# Altium schematic sheet User Feedback.SchDoc
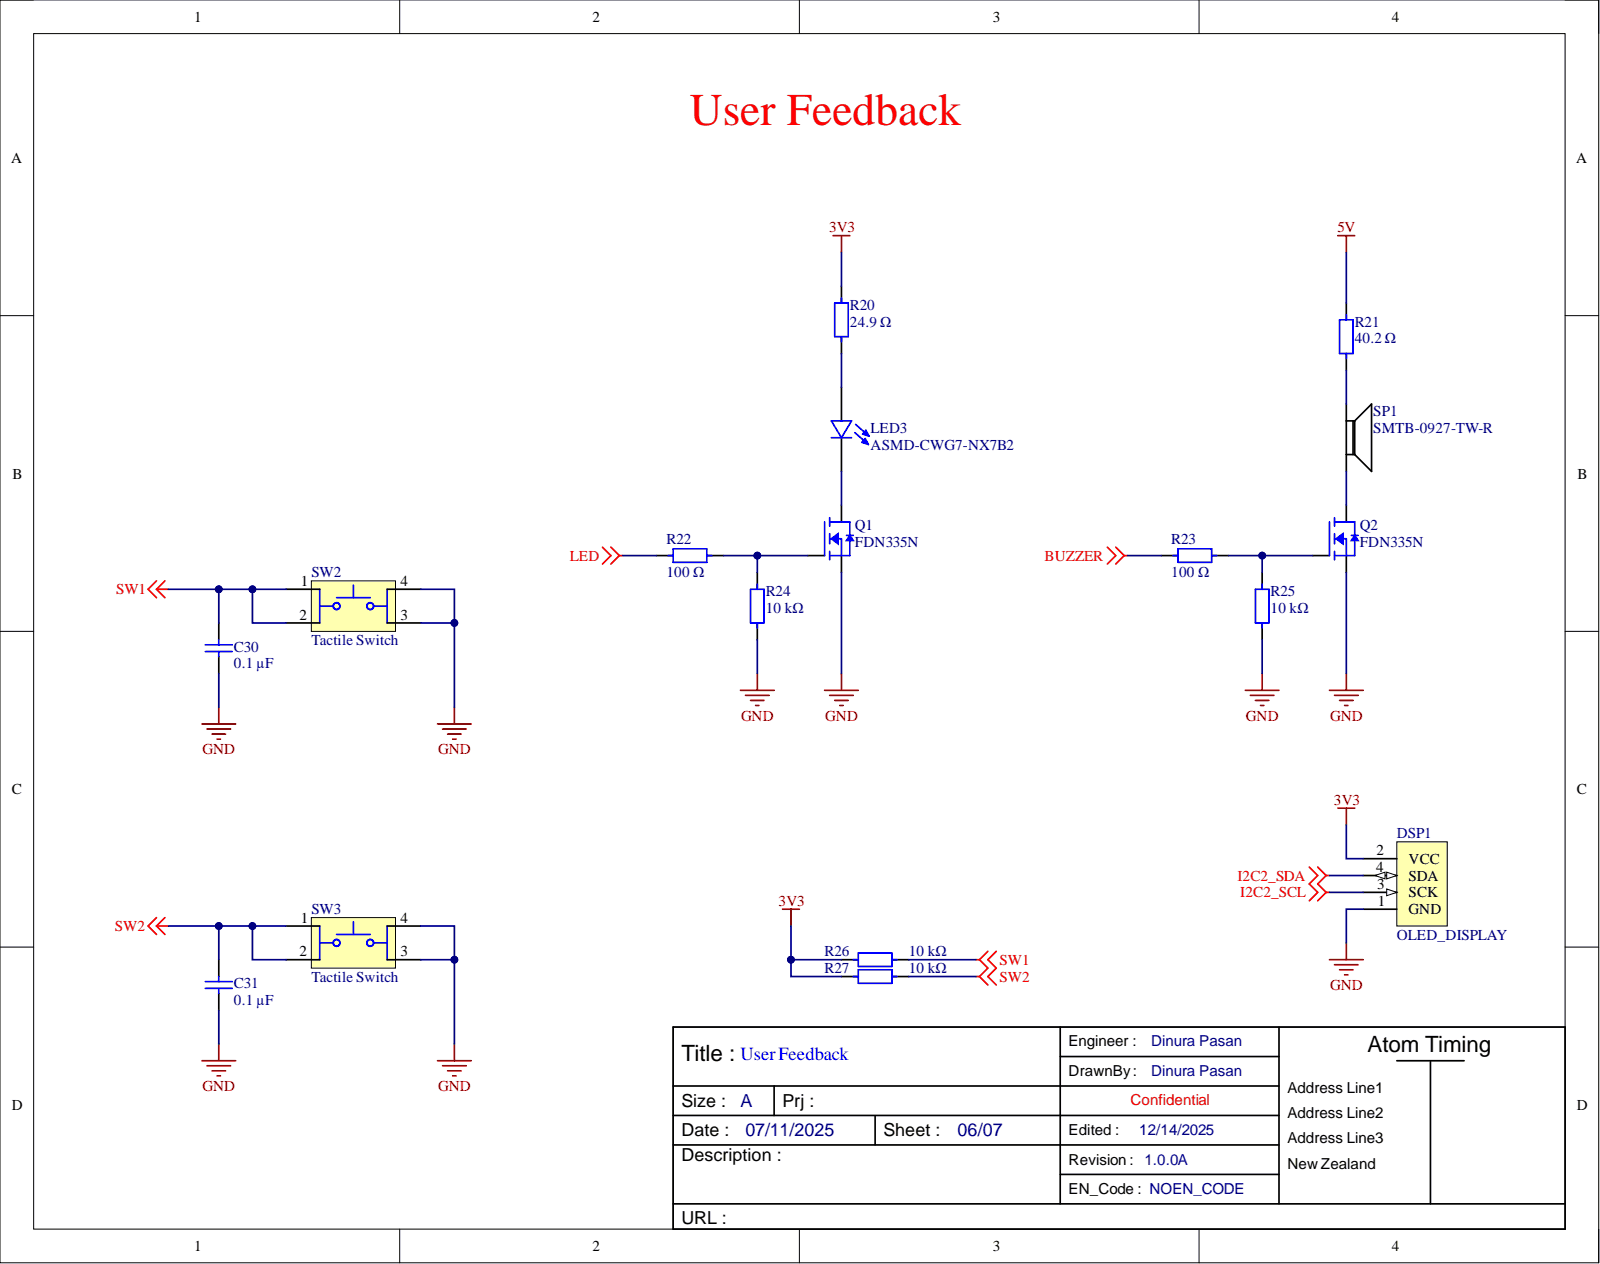
[diagram p0: User Feedback.SchDoc]

## Parts
C30  0.1 µF  [TDK_CGA0805N]
C31  0.1 µF  [TDK_CGA0805N]
DSP1  OLED_DISPLAY  [OLED_DISPLAY]
LED3  ASMD-CWG7-NX7B2  [ASMD-CWG7-NX7B2]
Q1  FDN335N  [FDN335N]
Q2  FDN335N  [FDN335N]
R20  24.9 Ω  [YAGEO_RT0603N]
R21  40.2 Ω  [YAGEO_RT0402N]
R22  100 Ω  [Panasonic_ERA2AN]
R23  100 Ω  [Panasonic_ERA2AN]
R24  10 kΩ  [Panasonic_ERA2AN]
R25  10 kΩ  [Panasonic_ERA2AN]
R26  10 kΩ  [Panasonic_ERA2AN]
R27  10 kΩ  [Panasonic_ERA2AN]
SP1  SMTB-0927-TW-R  [SMTB-0927-TW-R]
SW2  Tactile Switch  [TL3313AF160QG]
SW3  Tactile Switch  [TL3313AF160QG]

## Nets
3V3: DSP1.2(VCC) R20.2 R26.2 R27.2
5V: R21.2
BUZZER: R23.1
GND: C30.2 C31.2 DSP1.1(GND) Q1.S Q2.S R24.1 R25.1 SW2.3 SW2.4 SW3.3 SW3.4
I2C2_SCL: DSP1.3(SCK)
I2C2_SDA: DSP1.4(SDA)
LED: R22.1
SW1: C30.1 R26.1 SW2.1 SW2.2
SW2: C31.1 R27.1 SW3.1 SW3.2
NetLED3_A: LED3.A R20.1
NetLED3_K: LED3.K Q1.D
NetQ1_G: Q1.G R22.2 R24.2
NetQ2_D: Q2.D SP1.2
NetQ2_G: Q2.G R23.2 R25.2
NetR21_1: R21.1 SP1.1

## Library data of the parts
C30 C31 = CGA4J2X7R2A104K125AE: 0.1 µF ±10% 100V X7R -55°C ~ 125°C 0805 (2012 Metric); Manufacturer: TDK Corporation; Database Table Name: Sheet1$; Eco Plan: RoHS & Green; Initial Voltage: 0V; TEMP: 25; Value: 0.1u; Capacitance: 0.1 µF; Category: Ceramic Capacitor (MLCC); Component Kind: Standard; Datasheet: https://product.tdk.com/system/files/dam/doc/product/capacitor/ceramic/mlcc/catalog/mlcc_automotive_general_en.pdf; Library Name: MLCC.DbLib; Manufacturer Part Number: CGA4J2X7R2A104K125AE; Operating Temperature: -55°C ~ 125°C; Package / Case: 0805 (2012 Metric); Ratings: AEC-Q200; Series: CGA; Simulation: C_Capacitor_Default; Size / Dimension: 0.079" L x 0.049" W (2.00mm x 1.25mm); Supplier 1: Digi-Key; Supplier Part Number 1: 445-15961-2-ND; Temperature Coefficient: X7R; Thickness (Max): 0.059" (1.50mm); Tolerance: ±10%; Voltage - Rated: 100V
DSP1 = OLED_DISPLAY: 0.91 Inch 128X32 I2C White OLED Display; Component Kind: Standard; ComponentLink1Description: Product link; ComponentLink1URL: https://www.aliexpress.com/item/1005009650715831.html?spm=a2g0o.productlist.main.6.254cNLq4NLq4RG&algo_pvid=0f3c1311-dd3f-4e37-8da7-f4c64ae0268f&algo_exp_id=0f3c1311-dd3f-4e37-8da7-f4c64ae0268f-5&pdp_ext_f=%7B%22order%22%3A%223%22%2C%22eval%22%3A%221%22%2C%22fromPage%22%3A%22search%22%7D&pdp_npi=6%40dis%21LKR%212224.80%211335.56%21%21%216.53%213.92%21%400b1bf20a17628478987366382e60a1%2112000049770295659%21sea%21LK%212927457212%21ACX%211%210%21n_tag%3A-29919%3Bd%3Ae4d7efe4%3Bm03_new_user%3A-29894&curPageLogUid=7sszbpvwwOz4&utparam-url=scene%3Asearch%7Cquery_from%3A%7Cx_object_id%3A1005009650715831%7C_p_origin_prod%3A; Library Name: Components.IntLib
LED3 = ASMD-CWG7-NX7B2: White, Cool LED Indication - Discrete 2.8V 1206 (3216 Metric); Manufacturer 1: Avago; Category 1: LEDs; Description 1: LED COOL WHITE 3014 SMD "White, Cool LED Indication - Discrete 2.8V 1206 (3014 Metric)"; Forward Current: 30mA; Forward Voltage: 3.4V; Luminous Intensity: 2.24cd; Mount 1: Surface Mount; Number of Circuits: 1; Shape: Rectangular; Viewing Angle: 120°; Component Kind: Standard; ComponentLink1Description: https://datasheet.octopart.com:443/ASMD-CWG7-NX7B2-Broadcom-datasheet-179992970.pdf?src-supplier=IHS; ComponentLink1URL: https://datasheet.octopart.com:443/ASMD-CWG7-NX7B2-Broadcom-datasheet-179992970.pdf?src-supplier=IHS; Height: 700µm; Library Name: Components.IntLib; Lifecycle Status: Production; Manufacturer Part Number 1: ASMD-CWG7-NX7B2; Max Operating Temperature 1: 85°C; Min Operating Temperature 1: -40°C; Number of Terminals: 2; REACH SVHC: Yes; RoHS 1: Compliant; Supplier 1: DigiKey; Supplier Part Number 1: 516-ASMD-CWG7-NX7B2CT-ND; Terminal Pitch: 1.795mm
Q1 Q2 = FDN335N: N-Channel 2.5V Specified PowerTrench™ MOSFET 20V, 1.7A, 70mO; Manufacturer 1: onsemi; Manufacturer Lifecycle Status: ACTIVE; Manufacturer Package Identifier: CASE 527AG-01; Case/Package 1: SOT-23; Category 1: MOSFETs; Current: 17A; Description 1: N-Channel PowerTrench™ MOSFET, 2.5V Specified, 20V, 1.7A, 70mΩ; Drain to Source Resistance: 70mΩ; Dual Supply Voltage: 25V; Element Configuration: Single; Fall Time: 8.5ns; Gate to Source Voltage (Vgs): 8V; Input Capacitance: 310pF; Max Power Dissipation: 500mW; Mount 1: Surface Mount; Nominal Vgs: 800mV; Number of Elements: 1; Number of Pins 1: 3; Packaging 1: Cut Tape; Threshold Voltage: 900mV; Turn-Off Delay Time: 11ns; Turn-On Delay Time: 5ns; China RoHS: Compliant; Component Kind: Standard; ComponentLink1Description: https://datasheet.octopart.com:443/FDN335N-onsemi-datasheet-180959880.pdf?src-supplier=IHS; ComponentLink1URL: https://datasheet.octopart.com:443/FDN335N-onsemi-datasheet-180959880.pdf?src-supplier=IHS; ComponentLink2Description: https://datasheet.octopart.com:443/FDN335N-ON-Semiconductor-datasheet-9913.pdf?src-supplier=IHS; ComponentLink2URL: https://datasheet.octopart.com:443/FDN335N-ON-Semiconductor-datasheet-9913.pdf?src-supplier=IHS; ComponentLink3Description: https://datasheet.octopart.com:443/FDN335N-ON-Semiconductor-datasheet-97399168.pdf?src-supplier=JRH+Electronics; ComponentLink3URL: https://datasheet.octopart.com:443/FDN335N-ON-Semiconductor-datasheet-97399168.pdf?src-supplier=JRH+Electronics; ComponentLink4Description: https://datasheet.octopart.com:443/FDN335N-ON-Semiconductor-datasheet-85487652.pdf?src-supplier=onsemi; ComponentLink4URL: https://datasheet.octopart.com:443/FDN335N-ON-Semiconductor-datasheet-85487652.pdf?src-supplier=onsemi; ComponentLink5Description: https://datasheet.octopart.com:443/FDN335N-ON-Semiconductor-datasheet-86693735.pdf?src-supplier=onsemi; ComponentLink5URL: https://datasheet.octopart.com:443/FDN335N-ON-Semiconductor-datasheet-86693735.pdf?src-supplier=onsemi; ComponentLink6Description: https://datasheet.octopart.com:443/FDN335N-onsemi-datasheet-181351936.pdf?src-supplier=Farnell; ComponentLink6URL: https://datasheet.octopart.com:443/FDN335N-onsemi-datasheet-181351936.pdf?src-supplier=Farnell; ComponentLink7Description: https://datasheet.octopart.com:443/FDN335N-ON-Semiconductor-datasheet-86688453.pdf?src-supplier=Farnell; ComponentLink7URL: https://datasheet.octopart.com:443/FDN335N-ON-Semiconductor-datasheet-86688453.pdf?src-supplier=Farnell; ComponentLink8Description: https://datasheet.octopart.com:443/FDN335N-Fairchild-Semiconductor-datasheet-67131906.pdf?src-supplier=element14+APAC; ComponentLink8URL: https://datasheet.octopart.com:443/FDN335N-Fairchild-Semiconductor-datasheet-67131906.pdf?src-supplier=element14+APAC; ComponentLink9Description: https://datasheet.octopart.com:443/FDN335N-Fairchild-Semiconductor-datasheet-68449600.pdf?src-supplier=element14+APAC; ComponentLink9URL: https://datasheet.octopart.com:443/FDN335N-Fairchild-Semiconductor-datasheet-68449600.pdf?src-supplier=element14+APAC; ComponentLink10Description: https://datasheet.octopart.com:443/FDN335N-Fairchild-Semiconductor-datasheet-68479330.pdf?src-supplier=element14+APAC; ComponentLink10URL: https://datasheet.octopart.com:443/FDN335N-Fairchild-Semiconductor-datasheet-68479330.pdf?src-supplier=element14+APAC; ComponentLink11Description: https://datasheet.octopart.com:443/FDN335N-Fairchild-Semiconductor-datasheet-67356176.pdf?src-supplier=Newark; ComponentLink11URL: https://datasheet.octopart.com:443/FDN335N-Fairchild-Semiconductor-datasheet-67356176.pdf?src-supplier=Newark; ComponentLink12Description: https://datasheet.octopart.com:443/FDN335N-Fairchild-Semiconductor-datasheet-67809794.pdf?src-supplier=Newark; ComponentLink12URL: https://datasheet.octopart.com:443/FDN335N-Fairchild-Semiconductor-datasheet-67809794.pdf?src-supplier=Newark; ComponentLink13Description: https://datasheet.octopart.com:443/FDN335N.-Fairchild-Semiconductor-datasheet-8443005.pdf?src-supplier=Jameco; ComponentLink13URL: https://datasheet.octopart.com:443/FDN335N.-Fairchild-Semiconductor-datasheet-8443005.pdf?src-supplier=Jameco; ComponentLink14Description: https://sigma.octopart.com:443/17348131/technical_drawing/Fairchild-Semiconductor-FDN335N..pdf?src-supplier=Fairchild+Semiconductor; ComponentLink14URL: https://sigma.octopart.com:443/17348131/technical_drawing/Fairchild-Semiconductor-FDN335N..pdf?src-supplier=Fairchild+Semiconductor; ComponentLink15Description: https://datasheet.octopart.com:443/FDN335N-ON-Semiconductor-datasheet-67321133.pdf?src-supplier=TME; ComponentLink15URL: https://datasheet.octopart.com:443/FDN335N-ON-Semiconductor-datasheet-67321133.pdf?src-supplier=TME; ComponentLink16Description: http://sigma.octopart.com:80/150609247/rohs_statement/ON-Semiconductor-FDN335N.pdf?src-supplier=Compliance+Statements; ComponentLink16URL: http://sigma.octopart.com:80/150609247/rohs_statement/ON-Semiconductor-FDN335N.pdf?src-supplier=Compliance+Statements; ComponentLink17Description: http://sigma.octopart.com:80/17348145/reach_statement/Fairchild-Semiconductor-FDN335N..pdf?src-supplier=Compliance+Statements; ComponentLink17URL: http://sigma.octopart.com:80/17348145/reach_statement/Fairchild-Semiconductor-FDN335N..pdf?src-supplier=Compliance+Statements; ComponentLink18Description: http://sigma.octopart.com:80/17348146/materials_sheet/Fairchild-Semiconductor-FDN335N..pdf?src-supplier=Compliance+Statements; ComponentLink18URL: http://sigma.octopart.com:80/17348146/materials_sheet/Fairchild-Semiconductor-FDN335N..pdf?src-supplier=Compliance+Statements; ComponentLink19Description: http://sigma.octopart.com:80/33156581/reach_statement/Fairchild-Semiconductor-FDN335N..pdf?src-supplier=Compliance+Statements; ComponentLink19URL: http://sigma.octopart.com:80/33156581/reach_statement/Fairchild-Semiconductor-FDN335N..pdf?src-supplier=Compliance+Statements; ComponentLink20Description: http://sigma.octopart.com:80/33156590/materials_sheet/Fairchild-Semiconductor-FDN335N..pdf?src-supplier=Compliance+Statements; ComponentLink20URL: http://sigma.octopart.com:80/33156590/materials_sheet/Fairchild-Semiconductor-FDN335N..pdf?src-supplier=Compliance+Statements; ComponentLink21Description: http://sigma.octopart.com:80/reach_statement/ON-Semiconductor-company-79.pdf?src-supplier=Compliance+Statements; ComponentLink21URL: http://sigma.octopart.com:80/reach_statement/ON-Semiconductor-company-79.pdf?src-supplier=Compliance+Statements; ComponentLink22Description: http://sigma.octopart.com:80/reach_statement/Fairchild-Semiconductor-company-9.pdf?src-supplier=Compliance+Statements; ComponentLink22URL: http://sigma.octopart.com:80/reach_statement/Fairchild-Semiconductor-company-9.pdf?src-supplier=Compliance+Statements; ComponentLink23Description: http://sigma.octopart.com:80/rohs_statement/Fairchild-Semiconductor-company-10.pdf?src-supplier=Compliance+Statements; ComponentLink23URL: http://sigma.octopart.com:80/rohs_statement/Fairchild-Semiconductor-company-10.pdf?src-supplier=Compliance+Statements; ComponentLink24Description: http://sigma.octopart.com:80/rohs_statement/Fairchild-Semiconductor-company-11.pdf?src-supplier=Compliance+Statements; ComponentLink24URL: http://sigma.octopart.com:80/rohs_statement/Fairchild-Semiconductor-company-11.pdf?src-supplier=Compliance+Statements; ComponentLink25Description: https://octopart.com:443/opatz8j6/c1?t=ViIfpFJ8D9CtHbezx466yyyj4ks2o3lBVJ9GtJjMuFSaKv5Fbh7fHEtTSks_RcDYdshEbCjVxVPjbp8lQ1aXA_wulwThSqcr5PPLwD8xjJJP3YNT-0n0pJ27hxxMdZki_yXsRI91OUkBHTv1Jevq60Pmvr5JuWXGkQXv1S9kacBbzVKBVS5OrgaF4aiDlYx8YItlZQgqvj-PjFSYGdxhga3cw5-3u2_9ij1YmVjnrVh9yTahMGQCuY9M1mvDsHOD_rBU6P2tg-YyC8Qt9lntiu5nLUmXlcVW_Xk3r7_jcEkpoIc3P7XyjKgL_a1pvBMRcU_Bo2UAIgR4MsArNEIqZz17YVN0Sp3eES1T&src-supplier=Compliance+Statements; ComponentLink25URL: https://octopart.com:443/opatz8j6/c1?t=ViIfpFJ8D9CtHbezx466yyyj4ks2o3lBVJ9GtJjMuFSaKv5Fbh7fHEtTSks_RcDYdshEbCjVxVPjbp8lQ1aXA_wulwThSqcr5PPLwD8xjJJP3YNT-0n0pJ27hxxMdZki_yXsRI91OUkBHTv1Jevq60Pmvr5JuWXGkQXv1S9kacBbzVKBVS5OrgaF4aiDlYx8YItlZQgqvj-PjFSYGdxhga3cw5-3u2_9ij1YmVjnrVh9yTahMGQCuY9M1mvDsHOD_rBU6P2tg-YyC8Qt9lntiu5nLUmXlcVW_Xk3r7_jcEkpoIc3P7XyjKgL_a1pvBMRcU_Bo2UAIgR4MsArNEIqZz17YVN0Sp3eES1T&src-supplier=Compliance+Statements; ComponentLink26Description: https://octopart.com:443/opatz8j6/c1?t=Pc56Ae37FOQnWZnbhyqwP5IJnIK2q0Q9FTCyitqbEbN5ZF6DVTfXAoWQBci4zuHqVl7tq2mND_e29zL7wEAvUQ8eJbJ8rwW63inM-tQFsoqbs8uILJTuOv7Q16qhSw_QQ9L6T4ygkxSXPO7Zy4fMn3O2YFREeHSQJCxxVtBSoMigA--jjrCdDhhibGx7iJ9L0zO4TvZ7GL5CyHAX0TyKkIR3L2f2bcg1su1Z4Q9eDmJlwHPpMbu06gOg_ym31H05aX_jy3HBSr4RxG8F5zT49iIAJeAPfA7J6haiDPX461etAkrPu3skI96B9DMYRoGjMrikGTNuxRQlgnr5_R-3Buetb_EBGpwdR8s&src-supplier=Compliance+Statements; ComponentLink26URL: https://octopart.com:443/opatz8j6/c1?t=Pc56Ae37FOQnWZnbhyqwP5IJnIK2q0Q9FTCyitqbEbN5ZF6DVTfXAoWQBci4zuHqVl7tq2mND_e29zL7wEAvUQ8eJbJ8rwW63inM-tQFsoqbs8uILJTuOv7Q16qhSw_QQ9L6T4ygkxSXPO7Zy4fMn3O2YFREeHSQJCxxVtBSoMigA--jjrCdDhhibGx7iJ9L0zO4TvZ7GL5CyHAX0TyKkIR3L2f2bcg1su1Z4Q9eDmJlwHPpMbu06gOg_ym31H05aX_jy3HBSr4RxG8F5zT49iIAJeAPfA7J6haiDPX461etAkrPu3skI96B9DMYRoGjMrikGTNuxRQlgnr5_R-3Buetb_EBGpwdR8s&src-supplier=Compliance+Statements; Contact Plating: Tin; Continuous Drain Current (ID): 1.7A; Current Rating: 1.7A; Drain to Source Breakdown Voltage: 20V; Drain to Source Voltage (Vdss): 20V; Height: 940µm; Lead Free 1: Lead Free; Length: 2.92mm; Library Name: Components.IntLib; Lifecycle Status: Production; Manufacturer Part Number 1: FDN335N; Max Operating Temperature 1: 150°C; Min Breakdown Voltage: 20V; Min Operating Temperature 1: -55°C; Number of Terminals: 3; Power Dissipation 1: 500mW; Radiation Hardening: No; Rds On Max: 70mΩ; REACH SVHC: Yes; Resistance: 70MΩ; Rise Time: 8.5ns; RoHS 1: Compliant; Schedule B: 8541210080, 8541210080|8541210080|8541210080|8541210080|8541210080; Supplier 1: DigiKey; Supplier Part Number 1: FDN335NCT-ND; Termination: SMD/SMT; Voltage: 20V; Voltage Rating (DC): 20V; Width: 3.05mm
R20 = RT0603BRD0724R9L: 24.9 Ω ±0.1% 0.1W, 1/10W Thin Film 0603 (1608 Metric) 75V ±25ppm/°C -55°C ~ 155°C; Manufacturer: YAGEO; Database Table Name: Sheet1$; Eco Plan: RoHS & Green; Maximum Overload Voltage: 150V; Rated Voltage: √(PxR); TC: 25; TC1: 0; Value: 24.9Ω; Category: SMD Thin Film Resistor; Component Kind: Standard; Composition: Thin Film; Datasheet: https://www.yageo.com/upload/media/product/products/datasheet/rchip/PYu-RT_1-to-0.01_RoHS_L_14.pdf; Height - Seated (Max): 0.022" (0.55mm); Library Name: SMD_Thin_Film_Resistors.DbLib; Manufacturer Part Number: RT0603BRD0724R9L; Maximum Working Voltage: 75V; Operating Temperature: -55°C ~ 155°C; Package / Case: 0603 (1608 Metric); Power (Watts): 0.1W, 1/10W; Ratings: -; Resistance: 24.9 Ω; Series: RT; Simulation: R_Resistor_Default; Size / Dimension: 0.063" L x 0.031" W (1.60mm x 0.80mm); Supplier 1: Digi-Key; Supplier Part Number 1: YAG4511TR-ND; TC2: 0; TEMP: 25; Temperature Coefficient: ±25ppm/°C; Tolerance: ±0.1%
R21 = RT0402FRE0740R2L: 40.2 Ω ±1% 0.063W, 1/16W Thin Film 0402 (1005 Metric) 50V ±50ppm/°C -55°C ~ 155°C; Manufacturer: YAGEO; Database Table Name: Sheet1$; Eco Plan: RoHS & Green; Maximum Overload Voltage: 100V; Rated Voltage: √(PxR); TC: 50; TC1: 0; TEMP: 25; Value: 40.2Ω; Category: SMD Thin Film Resistor; Component Kind: Standard; Composition: Thin Film; Datasheet: https://www.yageo.com/upload/media/product/products/datasheet/rchip/PYu-RT_1-to-0.01_RoHS_L_14.pdf; Height - Seated (Max): 0.014" (0.35mm); Library Name: SMD_Thin_Film_Resistors.DbLib; Manufacturer Part Number: RT0402FRE0740R2L; Maximum Working Voltage: 50V; Operating Temperature: -55°C ~ 155°C; Package / Case: 0402 (1005 Metric); Power (Watts): 0.063W, 1/16W; Ratings: -; Resistance: 40.2 Ω; Series: RT; Simulation: R_Resistor_Default; Size / Dimension: 0.039" L x 0.020" W (1.00mm x 0.50mm); Supplier 1: Digi-Key; Supplier Part Number 1: 13-RT0402FRE0740R2LTR-ND; TC2: 0; Temperature Coefficient: ±50ppm/°C; Tolerance: ±1%
R22 R23 = ERA-2AEB101X: 100 Ω ±0.1% 0.063W, 1/16W Thin Film 0402 (1005 Metric) 50V ±25ppm/°C -55°C ~ 155°C; Manufacturer: Panasonic Electronic Components; Database Table Name: Sheet1$; Eco Plan: RoHS & Green; Maximum Overload Voltage: 100V; Rated Voltage: √(PxR); TC: 25; TC1: 0; Value: 100Ω; Category: SMD Thin Film Resistor; Component Kind: Standard; Composition: Thin Film; Datasheet: https://industrial.panasonic.com/cdbs/www-data/pdf/RDM0000/AOA0000C307.pdf; Height - Seated (Max): 0.016" (0.40mm); Library Name: SMD_Thin_Film_Resistors.DbLib; Manufacturer Part Number: ERA-2AEB101X; Maximum Working Voltage: 50V; Operating Temperature: -55°C ~ 155°C; Package / Case: 0402 (1005 Metric); Power (Watts): 0.063W, 1/16W; Ratings: AEC-Q200; Resistance: 100 Ω; Series: ERA; Simulation: R_Resistor_Default; Size / Dimension: 0.039" L x 0.020" W (1.00mm x 0.50mm); Supplier 1: Digi-Key; Supplier Part Number 1: P100DCTR-ND; TC2: 0; TEMP: 25; Temperature Coefficient: ±25ppm/°C; Tolerance: ±0.1%
R24-R27 = ERA-2ARB103X: 10 kΩ ±0.1% 0.063W, 1/16W Thin Film 0402 (1005 Metric) 50V ±10ppm/°C -55°C ~ 155°C; Manufacturer: Panasonic Electronic Components; Database Table Name: Sheet1$; Eco Plan: RoHS & Green; Maximum Overload Voltage: 100V; Rated Voltage: √(PxR); TC: 10; TC1: 0; TEMP: 25; Value: 10kΩ; Category: SMD Thin Film Resistor; Component Kind: Standard; Composition: Thin Film; Datasheet: https://industrial.panasonic.com/cdbs/www-data/pdf/RDM0000/AOA0000C307.pdf; Height - Seated (Max): 0.016" (0.40mm); Library Name: SMD_Thin_Film_Resistors.DbLib; Manufacturer Part Number: ERA-2ARB103X; Maximum Working Voltage: 50V; Operating Temperature: -55°C ~ 155°C; Package / Case: 0402 (1005 Metric); Power (Watts): 0.063W, 1/16W; Ratings: AEC-Q200; Resistance: 10 kΩ; Series: ERA; Simulation: R_Resistor_Default; Size / Dimension: 0.039" L x 0.020" W (1.00mm x 0.50mm); Supplier 1: Digi-Key; Supplier Part Number 1: P10KCPTR-ND; TC2: 0; Temperature Coefficient: ±10ppm/°C; Tolerance: ±0.1%
SP1 = SMTB-0927-TW-R: Transducer, Electro-Mechanical, 95 dBA, 2.7 kHz, Liquid Crystal Polymer, SMD; Manufacturer 1: PUI Audio; Category 1: Buzzer; Component Kind: Standard; ComponentLink1Description: https://datasheet.octopart.com:443/SMTB-0927-TW-R-PUI-Audio-datasheet-154249357.pdf?src-supplier=Master+Electronics; ComponentLink1URL: https://datasheet.octopart.com:443/SMTB-0927-TW-R-PUI-Audio-datasheet-154249357.pdf?src-supplier=Master+Electronics; Description 1: Transducer, Electro-Mechanical, 95 dBA, 2.7 kHz, Liquid Crystal Polymer, SMD; Library Name: Common_library.IntLib; Manufacturer Part Number 1: SMTB-0927-TW-R; REACH SVHC: Yes; RoHS 1: Compliant; Supplier 1: DigiKey; Supplier 2: Mouser; Supplier Part Number 1: 668-SMTB-0927-TW-RCT-ND; Supplier Part Number 2: 665-SMTB0927TWR
SW2 SW3 = TL3313AF160QG: Tactile Switch SPST-NO Top Actuated Surface Mount; Manufacturer: E-Switch; Actuator Length: 0.3mm; Actuator Type: Standard; Contact Resistance: 50mR; Current Rating: 50mA; Illumination Type: Non-Illuminated; Max Voltage Rating (DC): 12V; Mechanical Life: 100000Cycles; Operating Force: 160gf; Packaging 1: Tape and Reel; Switch Function: Off-Mom; Switch Travel: 0.25mm; Throw Configuration: SPST; Category: Tactile Switches; Component Kind: Standard; ComponentLink1Description: Datasheet; ComponentLink1URL: https://sten-eswitch-13110800-production.s3.amazonaws.com/system/asset/product_line/data_sheet/161/TL3313.pdf; Library Name: Common_library.IntLib; Manufacturer Part Number: TL3313AF160QG; Max Operating Temperature 1: 70°C; Min Operating Temperature 1: -20°C; Mount: Surface Mount; Orientation: Straight; Package Quantity: 4000; RoHS: No; RoHS Compliant 1: No; Series: TL3313; Supplier 1: Digi-Key; Supplier Part Number 1: EG6064CT-ND; Termination: Gull Wing; Voltage Rating (DC): 12V
